# Altium schematic sheet Protocol.SchDoc(Protocol)
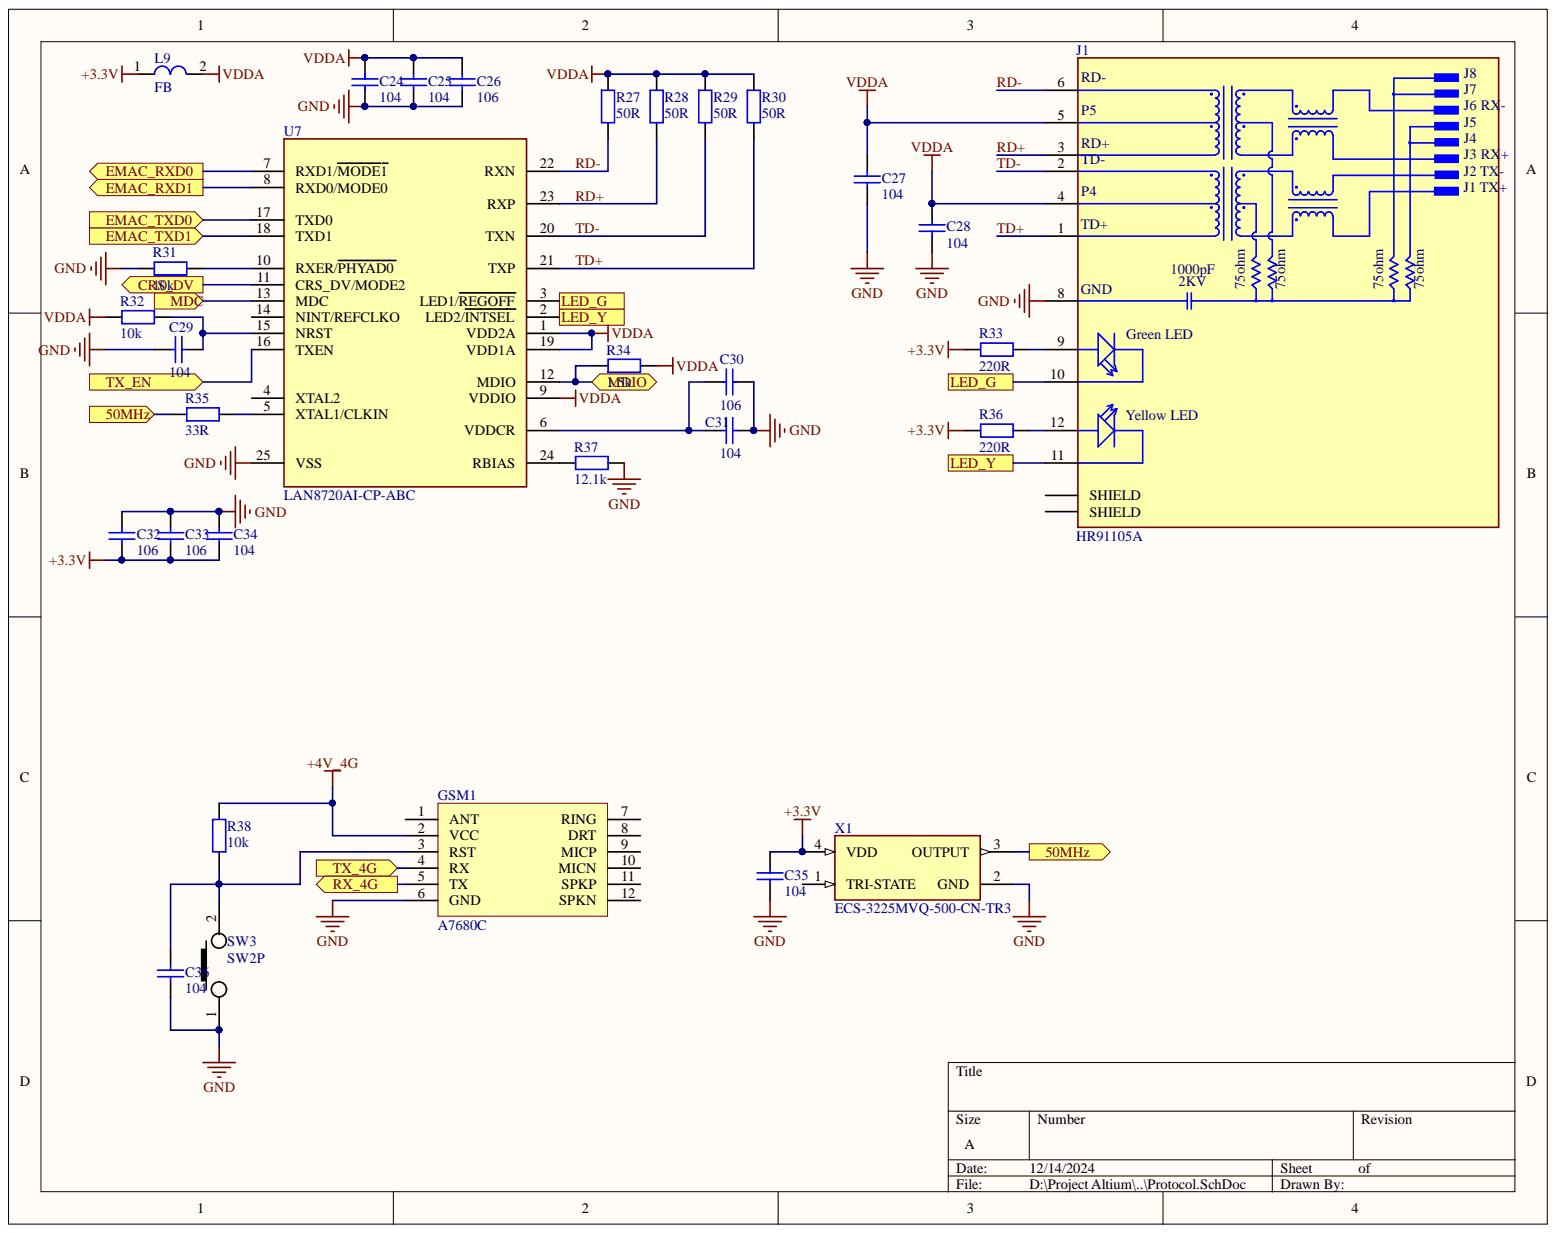
[diagram p0: Protocol.SchDoc]

## Parts
C24  104  [tu 0603]
C25  104  [tu 0603]
C26  106  [tu 0805]
C27  104  [tu 0603]
C28  104  [tu 0603]
C29  104  [tu 0603]
C30  106  [tu 0805]
C31  104  [tu 0603]
C32  106  [tu 0805]
C33  106  [tu 0805]
C34  104  [tu 0603]
C35  104  [tu 0603]
C36  104  [tu 0603]
GSM1  A7680C  [Module 4G A7680C]
J1  HR91105A  [HANRUN_HR911105A]
L9  FB  [FB0805 30 Ohm]
R27  50R  [tro smd 0603]
R28  50R  [tro smd 0603]
R29  50R  [tro smd 0603]
R30  50R  [tro smd 0603]
R31  10k  [tro smd 0603]
R32  10k  [tro smd 0603]
R33  220R  [tro smd 0603]
R34  1.5k  [tro smd 0603]
R35  33R  [tro smd 0603]
R36  220R  [tro smd 0603]
R37  12.1k  [tro smd 0603]
R38  10k  [tro smd 0603]
SW3  SW2P  [SW_2P_smd]
U7  LAN8720AI-CP-ABC  [LAN8720A]
X1  ECS-3225MVQ-500-CN-TR3  [OSC_ECS-3225MVQ-500-CN-TR3]

## Ports
50MHz, CRS_DV, EMAC_RXD0, EMAC_RXD1, EMAC_TXD0, EMAC_TXD1, LED_G, LED_Y, MDC, MDIO, RX_4G, TX_4G, TX_EN

## Nets
+3.3V: C32.2 C33.2 C34.2 C35.1 L9.1 R33.1 R36.1 X1.4(VDD)
+4V_4G: GSM1.2(VCC) R38.2
GND: C24.1 C25.1 C26.2 C27.1 C28.1 C29.2 C30.1 C31.1 C32.1 C33.1 C34.1 C35.2 C36.1 GSM1.6(GND) J1.8 R31.1 R37.2 SW3.1 U7.25(VSS) X1.2(GND)
VDDA: C24.2 C25.2 C26.1 C27.2 C28.2 J1.4 J1.5 L9.2 R27.1 R28.1 R29.1 R30.1 R32.1 R34.2 U7.1(VDD2A) U7.9(VDDIO) U7.19(VDD1A)
50MHz: R35.1 X1.3(OUTPUT) ; port 50MHz
CRS_DV: U7.11(CRS_DV/MODE2) ; port CRS_DV
EMAC_RXD0: U7.7(RXD1/MODE1) ; port EMAC_RXD0
EMAC_RXD1: U7.8(RXD0/MODE0) ; port EMAC_RXD1
EMAC_TXD0: U7.17(TXD0) ; port EMAC_TXD0
EMAC_TXD1: U7.18(TXD1) ; port EMAC_TXD1
LED_G: J1.10 U7.3(LED1/REGOFF) ; port LED_G
LED_Y: J1.11 U7.2(LED2/INTSEL) ; port LED_Y
MDC: U7.13(MDC) ; port MDC
MDIO: R34.1 U7.12(MDIO) ; port MDIO
RD+: J1.3(TD-) R28.2 U7.23(RXP)
RD-: J1.6 R27.2 U7.22(RXN)
RX_4G: GSM1.5(TX) ; port RX_4G
TD+: J1.1 R30.2 U7.21(TXP)
TD-: J1.2 R29.2 U7.20(TXN)
TX_4G: GSM1.4(RX) ; port TX_4G
TX_EN: U7.16(TXEN) ; port TX_EN
NetC29_1: C29.1 R32.2 U7.15(NRST)
NetC30_2: C30.2 C31.2 U7.6(VDDCR)
NetC36_2: C36.2 GSM1.3(RST) R38.1 SW3.2
NetJ1_9: J1.9 R33.2
NetJ1_12: J1.12 R36.2
NetR31_2: R31.2 U7.10(RXER/PHYAD0)
NetR35_2: R35.2 U7.5(XTAL1/CLKIN)
NetR37_1: R37.1 U7.24(RBIAS)

Not connected: GSM1 1(ANT) 7(RING) 8(DRT) 9(MICP) 10(MICN) 11(SPKP) 12(SPKN); J1 15(SHIELD) 16(SHIELD); U7 4(XTAL2) 14(NINT/REFCLKO); X1 1(TRI-STATE)

## Library data of the parts
C24 C25 C27-C29 C31 C34-C36 = Tu 0603 104 50V 10%: Dán; Ten_Linh_Kien: Tụ 0603 104-100nF 10% 50V; So_Chan: 2; Ma_Hang: MH004568; Don_Vi: Con; Library Name: Tu dien.SchLib; Component Kind: Standard; Chung_Loai: Dán
C26 C30 C32 C33 = Tu 0805 106 16V 10%: Dán; Ten_Linh_Kien: Tụ 0805 106-10uF 10% 16V; So_Chan: 2; Ma_Hang: MH004553; Don_Vi: Con; Library Name: Tu dien.SchLib; Component Kind: Standard; Chung_Loai: Dán
GSM1 = Module A7680C: Module Sim 4G A7680C; Library Name: test.SchLib; Component Kind: Standard
J1 = HR911105A: DIP RJ45 Connector;; MF: hanrun; SnapEDA_Link: https://www.snapeda.com/parts/HR911105A/HanRun/view-part/?ref=snap; Price: None; Package: None; MP: HR911105A; Library Name: test.SchLib; Component Kind: Standard; Check_prices: https://www.snapeda.com/parts/HR911105A/HanRun/view-part/?ref=eda; Availability: Not in stock
L9 = FB0805 30ohm: Ferrite 0805 30Ohm; Library Name: test.SchLib; Component Kind: Standard
R27-R30 = Tro 0603 1% 56R: Dán; Ten_Linh_Kien: Trở 0603 1% 56R; So_Chan: 2; Ma_Hang: MH006338; Don_Vi: Con; Library Name: Dien Tro.SchLib; Component Kind: Standard; Chung_Loai: Dán
R31 R32 R38 = Tro 0603 1% 10k: Dán; Ten_Linh_Kien: Trở 0603 1% 10k; So_Chan: 2; Ma_Hang: MH006333; Don_Vi: Con; Library Name: Dien Tro.SchLib; Component Kind: Standard; Chung_Loai: Dán
R33 R36 = Tro 0603 5% 220R: Dán; Ten_Linh_Kien: Trở 0603 5% 220R; So_Chan: 2; Ma_Hang: MH006322; Don_Vi: Con; Library Name: Dien Tro.SchLib; Component Kind: Standard; Chung_Loai: Dán
R34 = Tro 0603 5% 1.5k: Dán; Ten_Linh_Kien: Trở 0603 5% 1.5k; So_Chan: 2; Ma_Hang: MH006313; Don_Vi: Con; Library Name: Dien Tro.SchLib; Component Kind: Standard; Chung_Loai: Dán
R35 = Tro 0603 5% 33R: Dán; Ten_Linh_Kien: Trở 0603 5% 33R; So_Chan: 2; Ma_Hang: MH006326; Don_Vi: Con; Library Name: Dien Tro.SchLib; Component Kind: Standard; Chung_Loai: Dán
R37 = Tro 0603 5% 15k: Dán; Ten_Linh_Kien: Trở 0603 5% 15k; So_Chan: 2; Ma_Hang: MH004575; Don_Vi: Con; Library Name: Dien Tro.SchLib; Component Kind: Standard; Chung_Loai: Dán
SW3 = sw_2p_smd: Library Name: test.SchLib; Component Kind: Standard
U7 = LAN8720AI-CP-ABC: Microchip LAN8720AI-CP-ABC Ethernet Transceiver, IEEE802.3/802.3u, ISO 802-3/IEEE802.3, 100Mbit/s 3.3 V, 24-Pin QFN; Geometry.Height: 1mm; Mouser Price/Stock: https://www.mouser.co.uk/ProductDetail/Microchip-Technology/LAN8720AI-CP-ABC?qs=hxOdJ78B8ntmoAF5rclddw%3D%3D; Mouser Part Number: 579-LAN8720AI-CP-ABC; Manufacturer_Part_Number: LAN8720AI-CP-ABC; Manufacturer_Name: Microchip; Library Name: test.SchLib; Datasheet Link: http://www.microchip.com/mymicrochip/filehandler.aspx?ddocname=en586588; Component Kind: Standard
X1 = ECS-3225MVQ-500-CN-TR3: Standard Clock Oscillators 50.000 MHz Multi-Volt 1.6 - 3.6 V +/-25ppm -40 - +85C AEC-Q200 RoHS; MF: ECS; SnapEDA_Link: https://www.snapeda.com/parts/ECS-3225MVQ-500-CN-TR3/ECS/view-part/?ref=snap; Package: ECS-3225MVQ ECS Inc.; MP: ECS-3225MVQ-500-CN-TR3; Library Name: test.SchLib; Component Kind: Standard; Check_prices: https://www.snapeda.com/parts/ECS-3225MVQ-500-CN-TR3/ECS/view-part/?ref=eda
